# Altium schematic sheet Sheet1.SchDoc
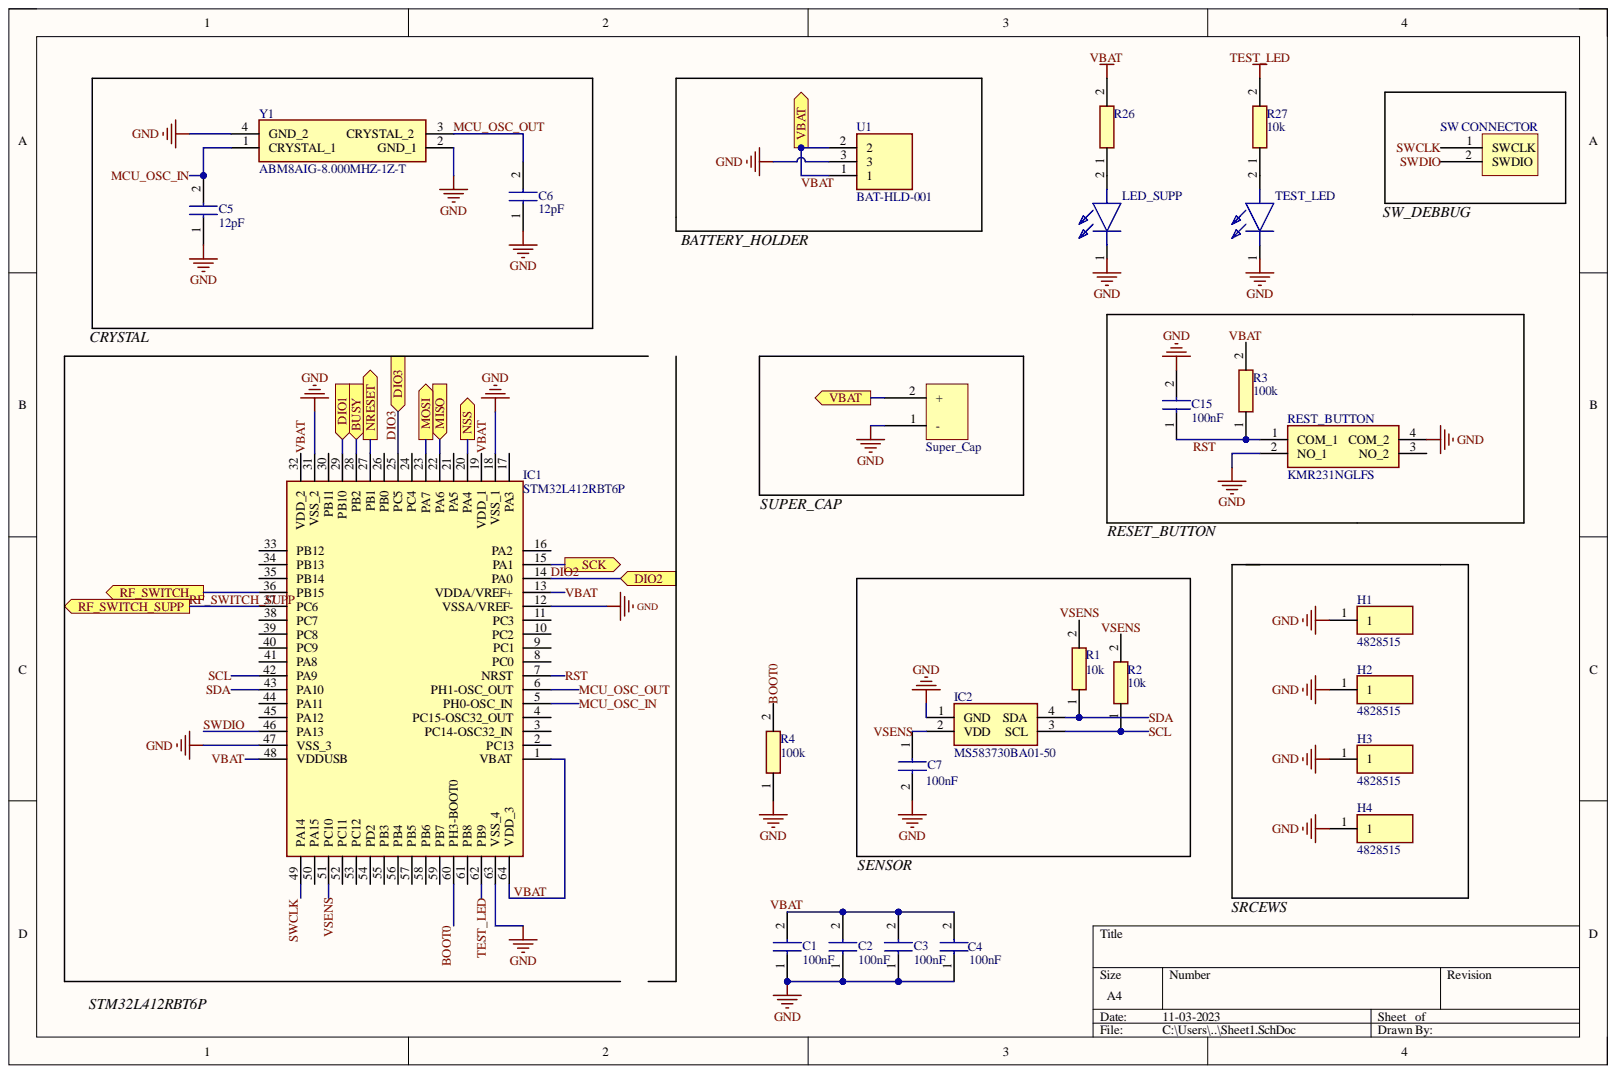
[diagram p0: Sheet1.SchDoc]

## Parts
*1  Super_Cap  [SUPERCAP]
C1  100nF  [CAPC1005X55N]
C2  100nF  [CAPC1005X55N]
C3  100nF  [CAPC1005X55N]
C4  100nF  [CAPC1005X55N]
C5  12pF  [CAPC1005X55N]
C6  12pF  [CAPC1005X55N]
C7  100nF  [CAPC1608X90N]
C15  100nF  [CAPC1005X55N]
H1  4828515  [4828515]
H2  4828515  [4828515]
H3  4828515  [4828515]
H4  4828515  [4828515]
IC1  STM32L412RBT6P  [QFP50P1200X1200X160-64N]
IC2  MS583730BA01-50  [MS583730BA0150]
LED_SUPP  TLMO1100-GS08  [LEDC1608X65N]  (value not drawn)
R1  10k  [RESC1005X35N]
R2  10k  [RESC1005X35N]
R3  100k  [RESC1005X35N]
R4  100k  [RESC1005X35N]
R26  (no value)  [RESC1005X35N]
R27  10k  [RESC1005X35N]
REST_BUTTON  KMR231NGLFS  [KMR231NGLFS]
SW_CONNECTOR  SERIAL_WIRE  [SERIAL_WIRE]  (value not drawn)
TEST_LED  TLMO1100-GS08  [LEDC1608X65N]  (value not drawn)
U1  BAT-HLD-001  [BATHLD001]
Y1  ABM8AIG-8.000MHZ-1Z-T  [ABM8AIG8000MHZ1ZT]

## Ports
BUSY, DIO1, DIO2, DIO3, MISO, MOSI, NRESET, NSS, RF_SWITCH, RF_SWITCH_SUPP, SCK, VBAT

## Nets
GND: *1.1 C1.1 C2.1 C3.1 C4.1 C5.1 C6.1 C7.2 C15.2 H1.1 H2.1 H3.1 H4.1 IC1.12(VSSA/VREF-) IC1.18(VSS_1) IC1.31(VSS_2) IC1.47(VSS_3) IC1.63(VSS_4) IC2.1(GND) LED_SUPP.1 R4.1 REST_BUTTON.2(NO_1) REST_BUTTON.4(COM_2) TEST_LED.1 U1.3 Y1.2(GND_1) Y1.4(GND_2)
BOOT0: IC1.60(PH3-BOOT0) R4.2
BUSY: IC1.28(PB2) ; port BUSY
DIO1: IC1.29(PB10) ; port DIO1
DIO2: IC1.14(PA0) ; port DIO2
DIO3: IC1.25(PC5) ; port DIO3
MCU_OSC_IN: C5.2 IC1.5(PH0-OSC_IN) Y1.1(CRYSTAL_1)
MCU_OSC_OUT: C6.2 IC1.6(PH1-OSC_OUT) Y1.3(CRYSTAL_2)
MISO: IC1.22(PA6) ; port MISO
MOSI: IC1.23(PA7) ; port MOSI
NRESET: IC1.27(PB1) ; port NRESET
NSS: IC1.20(PA4) ; port NSS
RF_SWITCH: IC1.36(PB15) ; port RF_SWITCH
RF_SWITCH_SUPP: IC1.37(PC6) ; port RF_SWITCH_SUPP
RST: C15.1 IC1.7(NRST) R3.1 REST_BUTTON.1(COM_1)
SCK: IC1.15(PA1) ; port SCK
SCL: IC1.42(PA9) IC2.3(SCL) R2.1
SDA: IC1.43(PA10) IC2.4(SDA) R1.1
SWCLK: IC1.49(PA14) SW_CONNECTOR.1
SWDIO: IC1.46(PA13) SW_CONNECTOR.2
TEST_LED: IC1.62(PB9) R27.2
VBAT: *1.2 C1.2 C2.2 C3.2 C4.2 IC1.1(VBAT) IC1.13(VDDA/VREF+) IC1.19(VDD_1) IC1.32(VDD_2) IC1.48(VDDUSB) IC1.64(VDD_3) R3.2 R26.2 U1.1 U1.2 ; port VBAT
VSENS: C7.1 IC1.51(PC10) IC2.2(VDD) R1.2 R2.2
NetLED_SUPP_2: LED_SUPP.2 R26.1
NetR27_1: R27.1 TEST_LED.2

Not connected: IC1 2(PC13) 3(PC14-OSC32_IN) 4(PC15-OSC32_OUT) 8(PC0) 9(PC1) 10(PC2) 11(PC3) 16(PA2) 17(PA3) 21(PA5) 24(PC4) 26(PB0) 30(PB11) 33(PB12) 34(PB13) 35(PB14) 38(PC7) 39(PC8) 40(PC9) 41(PA8) 44(PA11) 45(PA12) 50(PA15) 52(PC11) 53(PC12) 54(PD2) 55(PB3) 56(PB4) 57(PB5) 58(PB6) 59(PB7) 61(PB8); REST_BUTTON 3(NO_2)

## Library data of the parts
C1-C4 C15 = GRM155R61A224KE19D: 0402 Capacitor; Manufacturer_Name: Murata Electronics; Arrow Part Number: GRM155R61A224KE19D; Arrow Price/Stock: https://www.arrow.com/en/products/grm155r61a224ke19d/murata-manufacturing?region=nac; Component Kind: Standard; Datasheet Link: https://datasheet.datasheetarchive.com/originals/distributors/Datasheets_SAMA/ec4f1577dddcc7a13ddad897a28002d3.pdf; Height: 0.55mm; Manufacturer_Part_Number: GRM155R61A224KE19D; Mouser Part Number: 81-GRM155R61A224KE19; Mouser Price/Stock: https://www.mouser.co.uk/ProductDetail/Murata-Electronics/GRM155R61A224KE19D?qs=5bAuhL3RhmP2%252BUJqdGBuag%3D%3D
C5 C6 = GRM155R61A224KE19D: Capacitor; Manufacturer_Name: Murata Electronics; Arrow Part Number: GRM155R61A224KE19D; Arrow Price/Stock: https://www.arrow.com/en/products/grm155r61a224ke19d/murata-manufacturing?region=nac; Component Kind: Standard; Datasheet Link: https://datasheet.datasheetarchive.com/originals/distributors/Datasheets_SAMA/ec4f1577dddcc7a13ddad897a28002d3.pdf; Height: 0.55mm; Manufacturer_Part_Number: GRM155R61A224KE19D; Mouser Part Number: 81-GRM155R61A224KE19; Mouser Price/Stock: https://www.mouser.co.uk/ProductDetail/Murata-Electronics/GRM155R61A224KE19D?qs=5bAuhL3RhmP2%252BUJqdGBuag%3D%3D
C7 = GRM155R61A224KE19D: 0603 Capacitor; Manufacturer_Name: Murata Electronics; Arrow Part Number: GRM155R61A224KE19D; Arrow Price/Stock: https://www.arrow.com/en/products/grm155r61a224ke19d/murata-manufacturing?region=nac; Component Kind: Standard; Datasheet Link: https://datasheet.datasheetarchive.com/originals/distributors/Datasheets_SAMA/ec4f1577dddcc7a13ddad897a28002d3.pdf; Height: 0.55mm; Manufacturer_Part_Number: GRM155R61A224KE19D; Mouser Part Number: 81-GRM155R61A224KE19; Mouser Price/Stock: https://www.mouser.co.uk/ProductDetail/Murata-Electronics/GRM155R61A224KE19D?qs=5bAuhL3RhmP2%252BUJqdGBuag%3D%3D
H1-H4 = 4828515: Hardware; Manufacturer_Name: RS Components; Component Kind: Standard; Datasheet Link: https://www.alliedelec.com/m/d/4e41a0331e305dd17d985101135f1a89.pdf; Height: 1.8mm; Manufacturer_Part_Number: 4828515
IC1 = STM32L412RBT6P: Integrated Circuit; Manufacturer_Name: STMicroelectronics; Component Kind: Standard; Datasheet Link: https://www.st.com/resource/en/datasheet/stm32l412c8.pdf; Height: 1.6mm; Manufacturer_Part_Number: STM32L412RBT6P; Mouser Part Number: 511-STM32L412RBT6P; Mouser Price/Stock: https://www.mouser.co.uk/ProductDetail/STMicroelectronics/STM32L412RBT6P?qs=l7cgNqFNU1hh%252BU1wOZs5Xg%3D%3D
IC2 = MS583730BA01-50: Integrated Circuit; Manufacturer_Name: TE Connectivity; Arrow Part Number: MS583730BA01-50; Arrow Price/Stock: https://www.arrow.com/en/products/ms583730ba01-50/te-connectivity?region=europe; Component Kind: Standard; Datasheet Link: https://www.te.com/commerce/DocumentDelivery/DDEController?Action=srchrtrv&DocNm=MS5837-30BA&DocType=DS&DocLang=English; Manufacturer_Part_Number: MS583730BA01-50; Mouser Part Number: 824-MS583730BA01-50; Mouser Price/Stock: https://www.mouser.co.uk/ProductDetail/Measurement-Specialties/MS583730BA01-50?qs=SvmF4ZFxmIz%2F53mFym%252BZPQ%3D%3D
LED_SUPP TEST_LED = TLMO1100-GS08: LED; Manufacturer_Name: Vishay; Arrow Part Number: TLMO1100-GS08; Arrow Price/Stock: https://www.arrow.com/en/products/tlmo1100-gs08/vishay?region=nac; Component Kind: Standard; Datasheet Link: https://datasheet.datasheetarchive.com/originals/distributors/Datasheets-DGA13/1154391.pdf; Height: 0.65mm; Manufacturer_Part_Number: TLMO1100-GS08; Mouser Part Number: 78-TLMO1100-GS08; Mouser Price/Stock: https://www.mouser.co.uk/ProductDetail/Vishay-Semiconductors/TLMO1100-GS08?qs=%252BxyxD9GtKu3ItBf2l5qCow%3D%3D
R1-R4 R26 R27 = CPF0402B107KE1: 0402 Resistor; Manufacturer_Name: TE Connectivity; Component Kind: Standard; Datasheet Link: http://www.farnell.com/datasheets/1786775.pdf; Height: 0.35mm; Manufacturer_Part_Number: CPF0402B107KE1; Mouser Part Number: 279-CPF0402B107KE1; Mouser Price/Stock: https://www.mouser.co.uk/ProductDetail/TE-Connectivity-Neohm/CPF0402B107KE1?qs=CXI0wBT1M2hGHjTlP2dotA%3D%3D
REST_BUTTON = KMR231NGLFS: Switch; Manufacturer_Name: C & K COMPONENTS; Arrow Part Number: KMR231NGLFS; Arrow Price/Stock: https://www.arrow.com/en/products/kmr231nglfs/ck; Component Kind: Standard; Datasheet Link: https://www.ckswitches.com/media/1479/kmr2.pdf; Height: 1.9mm; Manufacturer_Part_Number: KMR231NGLFS; Mouser Part Number: 611-KMR231NGLFS; Mouser Price/Stock: https://www.mouser.co.uk/ProductDetail/CK/KMR231NGLFS?qs=sb%252But3Lm4x1Y5NsXXdZx2g%3D%3D
U1 = BAT-HLD-001: Undefined or Miscellaneous; Manufacturer_Name: Linx Technologies; Component Kind: Standard; Datasheet Link: https://www.mouser.com/ds/2/238/bat-hld-001-220194.pdf; Height: 4mm; Manufacturer_Part_Number: BAT-HLD-001; Mouser Part Number: 712-BAT-HLD-001; Mouser Price/Stock: https://www.mouser.co.uk/ProductDetail/Linx-Technologies/BAT-HLD-001?qs=K5ta8V%252BWhta7hbVGfm4dqA%3D%3D
Y1 = ABM8AIG-8.000MHZ-1Z-T: Crystal or Oscillator; Manufacturer_Name: ABRACON; Arrow Part Number: ABM8AIG-8.000MHZ-1Z-T; Arrow Price/Stock: https://www.arrow.com/en/products/abm8aig-8.000mhz-1z-t/abracon?region=nac; Component Kind: Standard; Datasheet Link: https://abracon.com/AIGcrystals/ABM8AIG.pdf; Height: 0.8mm; Manufacturer_Part_Number: ABM8AIG-8.000MHZ-1Z-T; Mouser Part Number: 815-M8AIG-8-1ZT; Mouser Price/Stock: https://www.mouser.co.uk/ProductDetail/ABRACON/ABM8AIG-8.000MHZ-1Z-T?qs=uwxL4vQweFM9%2FeRu4wQgCQ%3D%3D
*1 = Super_Cap: Component Kind: Standard; Library Name: TPMS_CustomLib.SchLib
SW_CONNECTOR = SERIAL_WIRE: Component Kind: Standard; Library Name: TPMS_CustomLib.SchLib
